# Altium schematic sheet KD_Subsystem.SchDoc
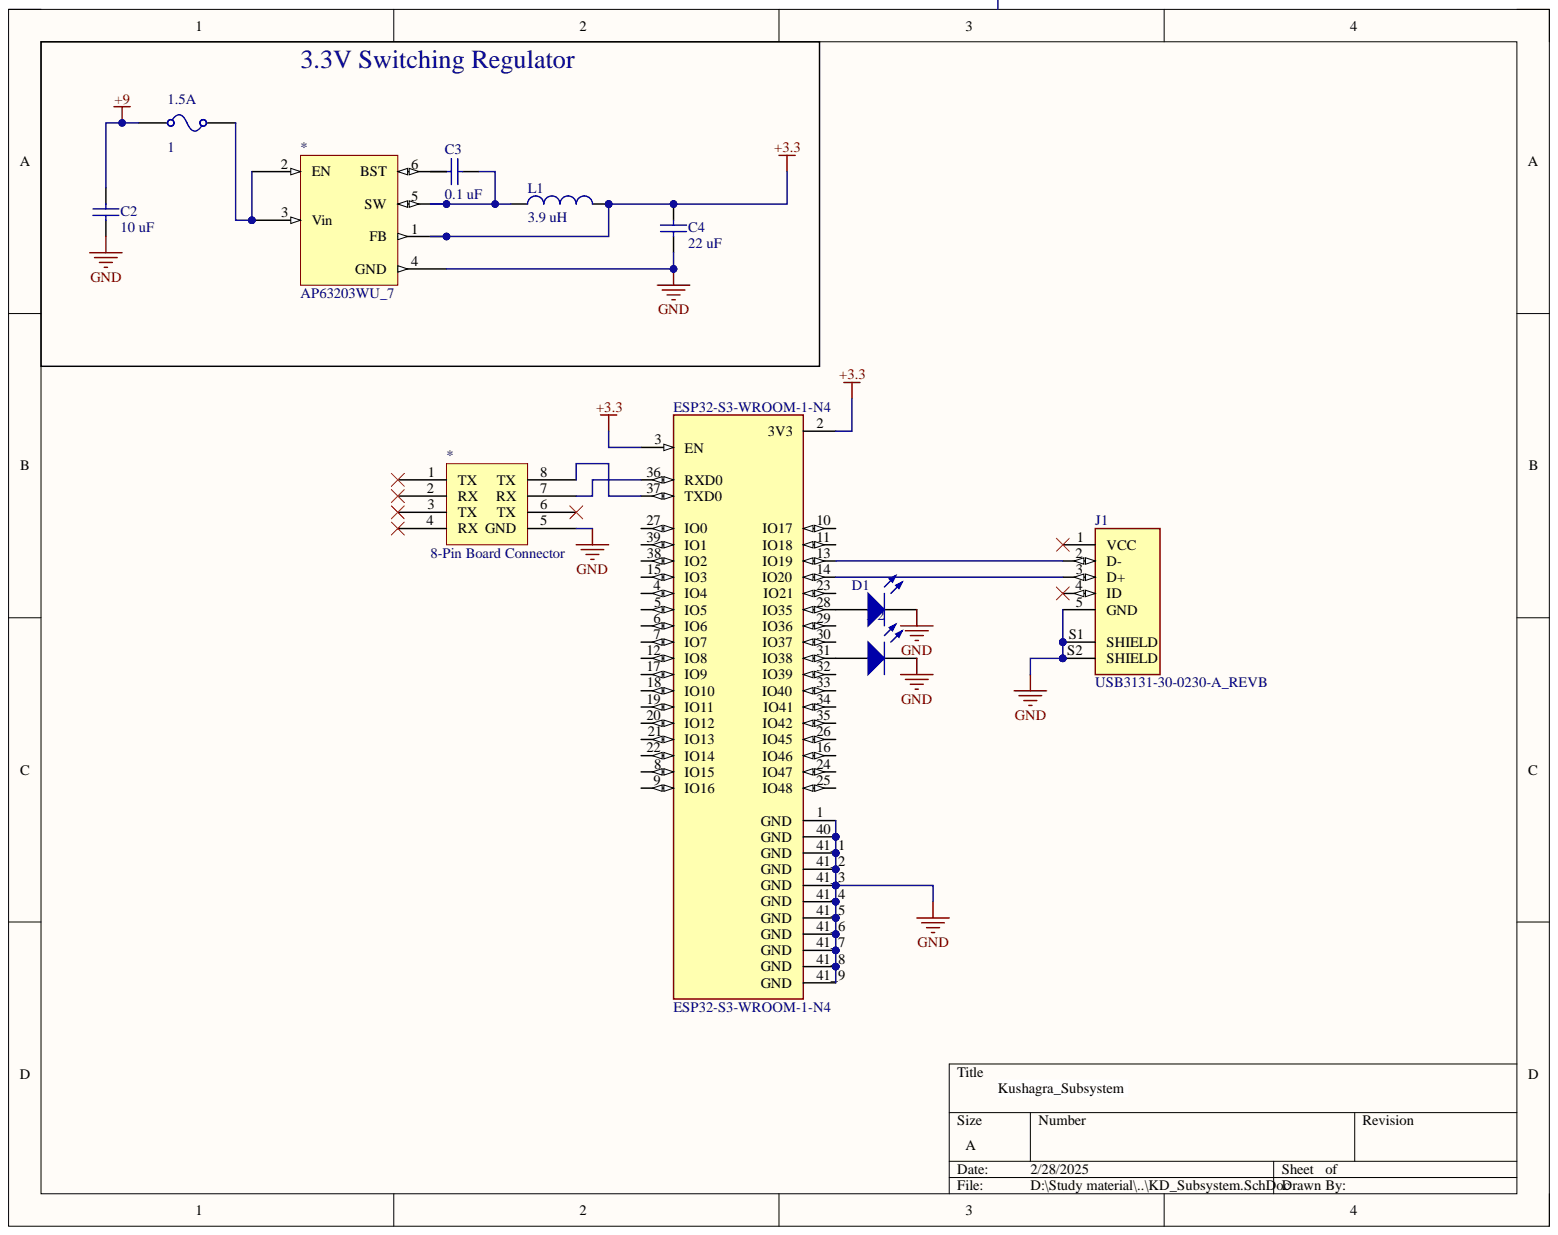
[diagram p0: KD_Subsystem.SchDoc]

## Parts
1.5A  Fuse  (value not drawn)
*  AP63203WU_7
*  8-Pin Board Connector
C2  value 10 uF  (hidden comment: Capacitor)
C3  value 0.1 uF  (hidden comment: Capacitor)
C4  value 22 uF  (hidden comment: Capacitor)
D1  Light-Emitting Diode  (value not drawn)
D2  Light-Emitting Diode  (value not drawn)
ESP32-S3-WROOM-1-N4  ESP32-S3-WROOM-1-N4  [XCVR_ESP32-S3-WROOM-1-N4]
J1  USB3131-30-0230-A_REVB  [GCT_USB3131-30-0230-A_REVB]
L1  value 3.9 uH  (hidden comment: Inductor)

## Nets
+3.3: *.1 C4.2 ESP32-S3-WROOM-1-N4.2(3V3) ESP32-S3-WROOM-1-N4.3(EN) L1.2
+9: 1.5A.1 C2.1
GND: *.4 *.5 C2.2 C4.1 D1.2 D2.2 ESP32-S3-WROOM-1-N4.1(GND) ESP32-S3-WROOM-1-N4.40(GND) ESP32-S3-WROOM-1-N4.41_1(GND) ESP32-S3-WROOM-1-N4.41_2(GND) ESP32-S3-WROOM-1-N4.41_3(GND) ESP32-S3-WROOM-1-N4.41_4(GND) ESP32-S3-WROOM-1-N4.41_5(GND) ESP32-S3-WROOM-1-N4.41_6(GND) ESP32-S3-WROOM-1-N4.41_7(GND) ESP32-S3-WROOM-1-N4.41_8(GND) ESP32-S3-WROOM-1-N4.41_9(GND) J1.5(GND) J1.S1(SHIELD) J1.S2(SHIELD)
Net1.5A_2: 1.5A.2 *.2 *.3
Net*_5: *.5 C3.1 L1.1
Net*_7: *.7(RX) ESP32-S3-WROOM-1-N4.36(RXD0)
Net*_8: *.8(TX) ESP32-S3-WROOM-1-N4.37(TXD0)
NetD1_1: D1.1 ESP32-S3-WROOM-1-N4.28(IO35)
NetD2_1: D2.1 ESP32-S3-WROOM-1-N4.31(IO38)
NetESP32-S3-WROOM-1-N4_13: ESP32-S3-WROOM-1-N4.13(IO19) J1.2(D-)
NetESP32-S3-WROOM-1-N4_14: ESP32-S3-WROOM-1-N4.14(IO20) J1.3(D+)

Not connected: * 6; C3 2; ESP32-S3-WROOM-1-N4 4(IO4) 5(IO5) 6(IO6) 7(IO7) 8(IO15) 9(IO16) 10(IO17) 11(IO18) 12(IO8) 15(IO3) 16(IO46) 17(IO9) 18(IO10) 19(IO11) 20(IO12) 21(IO13) 22(IO14) 23(IO21) 24(IO47) 25(IO48) 26(IO45) 27(IO0) 29(IO36) 30(IO37) 32(IO39) 33(IO40) 34(IO41) 35(IO42) 38(IO2) 39(IO1); J1 1(VCC) 4(ID)

## Library data of the parts
1.5A = Fuse: CURRENT: 1; RESISTANCE: 1m; SimulationGenericComponent: True; Component Kind: Standard; Description: Fuse; Library Name: Simulation Generic Components.IntLib; Simulation: FUSE
C2-C4 = Capacitor: SimulationGenericComponent: True; Component Kind: Standard; Description: Capacitor; Library Name: Simulation Generic Components.IntLib; Simulation: C_Capacitor_Default
D1 D2 = LED: AF: 1; BV: 0; BV_MAX: 1e99; EG: 1.11; FC: 0.5; IBV: 0.001; IS: 1e-14; MJSW: 0.33; NTUN: 30; SimulationGenericComponent: True; TNOM: 27; XTI: 3; CJO: 0; CJP: 0; Component Kind: Standard; CTA: 0; CTP: 0; Description: Light-Emitting Diode; FCS: 0.5; FV_MAX: 1e99; IKF: 0; IKR: 0; ISR: 1e-14; JSW: 0; JTUN: 0; JTUNSW: 0; KEG: 1; KF: 0; LEVEL: 1; Library Name: Simulation Generic Components.IntLib; N: 1; NBV: 1; NR: 1; NS: 1; PHP: 1; RS: 0; Simulation: diode; TCV: 0; TLEV: 0; TLEVC: 0; TM1: 0; TM2: 0; TPB: 0; TPHP: 0; TRS: 0; TRS2: 0; TT: 0; TTT1: 0; TTT2: 0; VJ: 1; XTITUN: 3
ESP32-S3-WROOM-1-N4 = CMP-002-000000-1: MF: {'manufacturer': u'Espressif Systems'}; Availability: Unavailable; Component Kind: Standard; Component Type: Standard; Design Item ID: ESP32-S3-WROOM-1-N4; MP: ESP32-S3-WROOM-1-N4; Package: None; Pin Count: 49; Price: None; Source: ESP32-S3-WROOM-1-N4.SchLib; Library Name: MacroChip
J1 = CMP-008-000000-1: USB - micro B USB 2.0 Receptacle Connector 5 Position Through Hole; Availability: Unavailable; Component Kind: Standard; Component Type: Standard; Design Item ID: USB3131-30-0230-A_REVB; MP: USB3131-30-0230-A_REVB; Package: None; Pin Count: 7; Price: None; Source: USB3131-30-0230-A_REVB.SchLib; Library Name: MacroChip
L1 = Inductor: SimulationGenericComponent: True; Component Kind: Standard; Description: Inductor; Library Name: Simulation Generic Components.IntLib; Simulation: L_Inductor_Default
* = AP63203WU_7: Component Kind: Standard; Library Name: KD_Library.SchLib
* = 8-Pin Board Connector: Component Kind: Standard; Library Name: KD_Library.SchLib
